# Altium schematic sheet WIFI.SchDoc
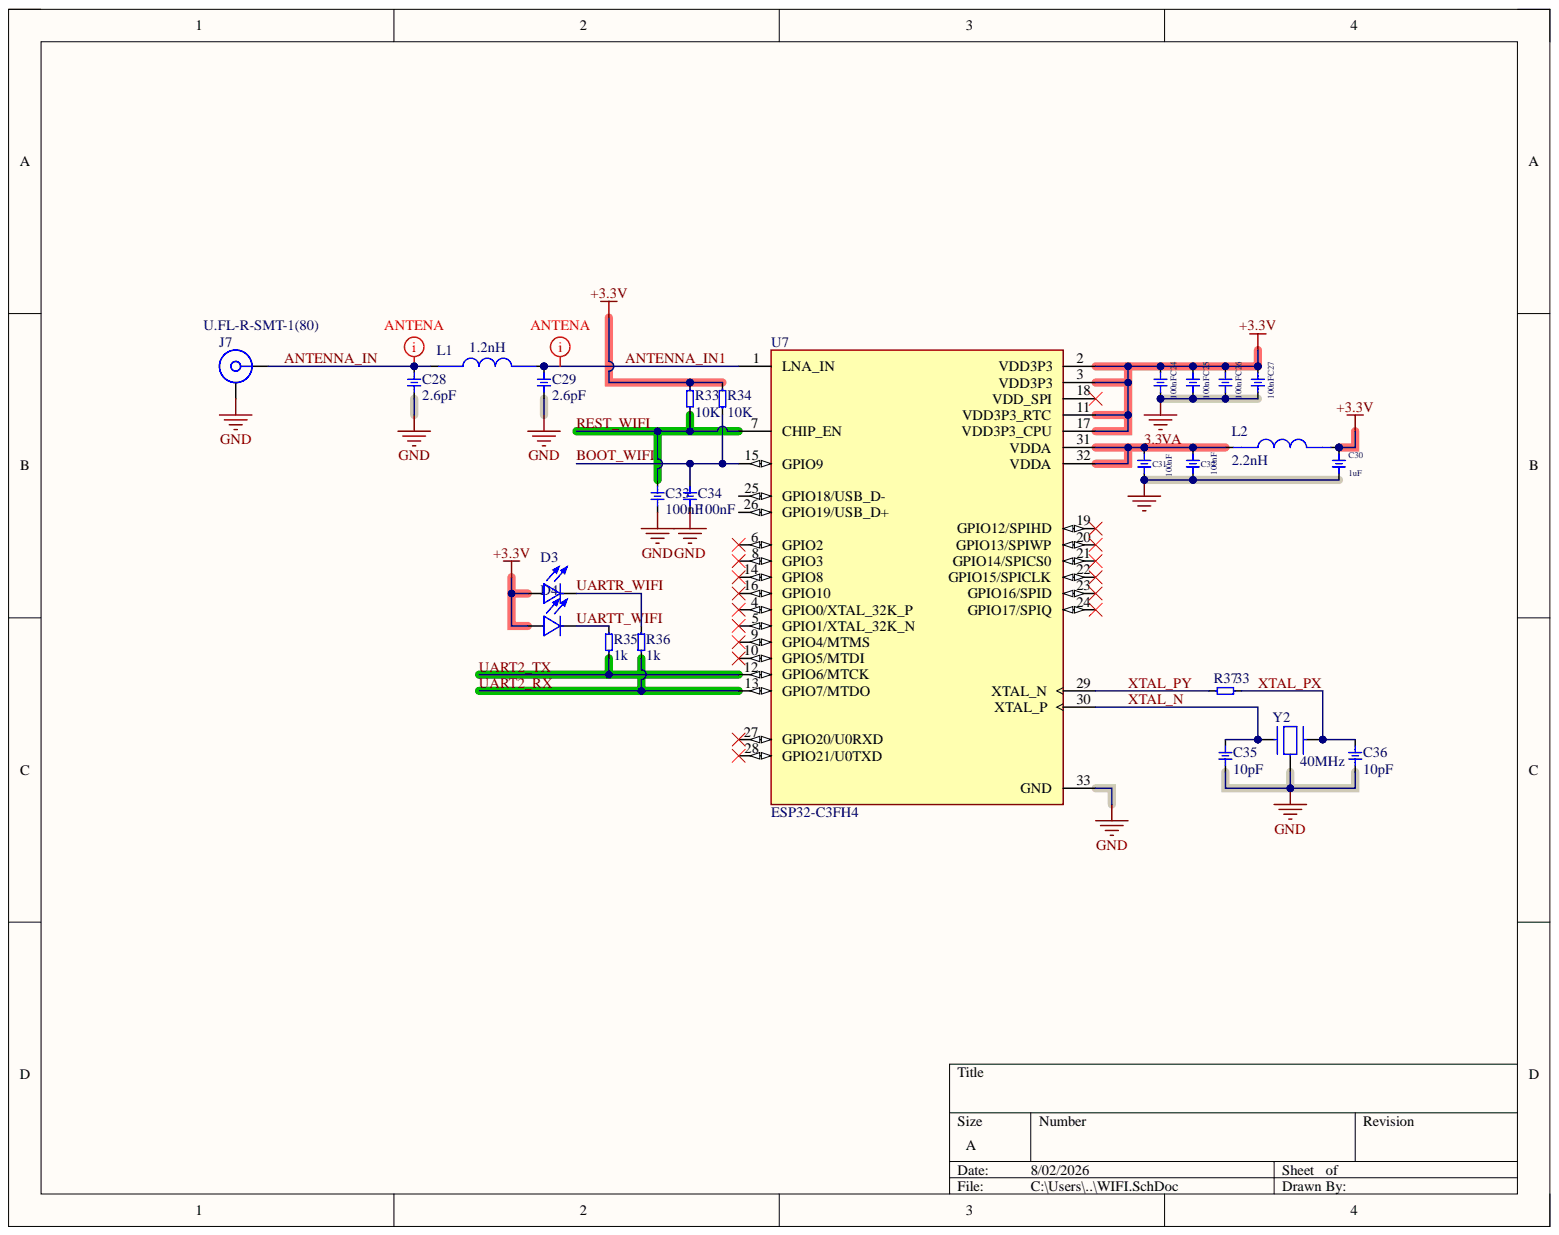
[diagram p0: WIFI.SchDoc]

## Parts
C24  100nF  [CAP_0402]
C25  100nF  [CAP_0402]
C26  100nF  [CAP_0402]
C27  100nF  [CAP_0402]
C28  2.6pF  [CAP_0402]
C29  2.6pF  [CAP_0402]
C30  1uF  [CAP_0402]
C31  100nF  [CAP_0402]
C32  100nF  [CAP_0402]
C33  100nF  [CAP_0402]
C34  100nF  [CAP_0402]
C35  10pF  [CAP_0402]
C36  10pF  [CAP_0402]
D3  LED_0603  [LED_0603]  (value not drawn)
D4  LED_0603  [LED_0603]  (value not drawn)
J7  U.FL-R-SMT-1(80)  [HIROSE_U.FL-R-SMT-1(80)]
L1  1.2nH  [IND_0402]
L2  2.2nH  [IND_0402]
R33  10K  [RES_0402]
R34  10K  [RES_0402]
R35  1k  [RES_0603]
R36  1k  [RES_0603]
R37  33  [RES_0402]  (value not drawn)
U7  ESP32-C3FH4  [VFQFN-32]
Y2  40MHz  [Xtai_3325_4P]

## Nets
+3.3V: C24.2 C25.2 C26.2 C27.2 C30.2 D3.A D4.A L2.2 R33.2 R34.2 U7.2(VDD3P3) U7.3(VDD3P3) U7.11(VDD3P3_RTC) U7.17(VDD3P3_CPU)
GND: C24.1 C25.1 C26.1 C27.1 C28.1 C29.1 C30.1 C31.1 C32.1 C33.1 C34.1 C35.1 C36.1 J7.G1 U7.33(GND) Y2.4
3.3VA: C31.2 C32.2 L2.1 U7.31(VDDA) U7.32(VDDA)
ANTENNA_IN: C28.2 J7.SIG L1.1
ANTENNA_IN1: C29.2 L1.2 U7.1(LNA_IN)
BOOT_WIFI: C34.2 R34.1 U7.15(GPIO9)
REST_WIFI: C33.2 R33.1 U7.7(CHIP_EN)
UART2_RX: R36.1 U7.13(GPIO7/MTDO)
UART2_TX: R35.1 U7.12(GPIO6/MTCK)
UARTR_WIFI: D3.C R36.2
UARTT_WIFI: D4.C R35.2
XTAL_N: C35.2 U7.30(XTAL_P) Y2.1
XTAL_PX: C36.2 R37.2 Y2.3
XTAL_PY: R37.1 U7.29(XTAL_N)

Not connected: U7 4(GPIO0/XTAL_32K_P) 5(GPIO1/XTAL_32K_N) 6(GPIO2) 8(GPIO3) 9(GPIO4/MTMS) 10(GPIO5/MTDI) 14(GPIO8) 16(GPIO10) 18(VDD_SPI) 19(GPIO12/SPIHD) 20(GPIO13/SPIWP) 21(GPIO14/SPICS0) 22(GPIO15/SPICLK) 23(GPIO16/SPID) 24(GPIO17/SPIQ) 25(GPIO18/USB_D-) 26(GPIO19/USB_D+) 27(GPIO20/U0RXD) 28(GPIO21/U0TXD)

## Library data of the parts
C24-C36 = CAP_0402: Component Kind: Standard; Library Name: RQSchlib.SchLib
D3 D4 = LED_0603: LED SMD 0603 Ultra Yellow 593nm 70mcd Water Clear Lens 130 Deg Viewing Angle | Bivar Inc. SM0603UYC; Check_prices: https://www.snapeda.com/parts/SM0603UYC/Bivar/view-part/?ref=eda; Component Kind: Standard; MF: Bivar; MP: SM0603UYC; Package: 0603 Bivar; SnapEDA_Link: https://www.snapeda.com/parts/SM0603UYC/Bivar/view-part/?ref=snap; Library Name: RQSchlib.SchLib
L1 L2 = IND_0402: 2 nH Unshielded Multilayer Inductor 900 mA 150mOhm Max 0402 (1005 Metric); MF: TDK; MP: MLG1005S2N0BT000; Check_prices: https://www.snapeda.com/parts/MLG1005S2N0BT000/TDK/view-part/?ref=eda; Component Kind: Standard; Digi-Key_Part_Number: 445-16277-2-ND; Package: 1005-2 TDK; Purchase-URL: https://pricing.snapeda.com/search?q=MLG1005S2N0BT000&ref=eda; SnapEDA_Link: https://www.snapeda.com/parts/MLG1005S2N0BT000/TDK/view-part/?ref=snap; Library Name: RQSchlib.SchLib
R33 R34 R37 = RES_0402: Component Kind: Standard; Library Name: RQSchlib.SchLib
R35 R36 = RES_0603: Component Kind: Standard; Library Name: RQSchlib.SchLib
Y2 = Xtal_3325: Crystal - 48MHz Â±10ppm - 10 pF - Â±15 ppm - -30 to +85Â°C 3225 Low aging; MF: ECS Inc.; MP: ECS-480-8-33B-CWY-TR3; Check_prices: https://www.snapeda.com/parts/ECS-480-8-33B-CWY-TR3/ECS/view-part/?ref=eda; Component Kind: Standard; Package: ECS-33B ECS Inc.; SnapEDA_Link: https://www.snapeda.com/parts/ECS-480-8-33B-CWY-TR3/ECS/view-part/?ref=snap; Library Name: RQSchlib.SchLib
J7 = U.FL-R-SMT-1(80): Availability: Unavailable; Component Kind: Standard; MP: U.FL-R-SMT-1(80); Package: None; Price: None; Library Name: RQSchlib.SchLib
U7 = ESP32-C3FH4: Availability: Unavailable; Component Kind: Standard; MP: ESP32-C3FH4; Package: None; Price: None; Library Name: RQSchlib.SchLib
